# Altium schematic sheet Power.SchDoc(Power)
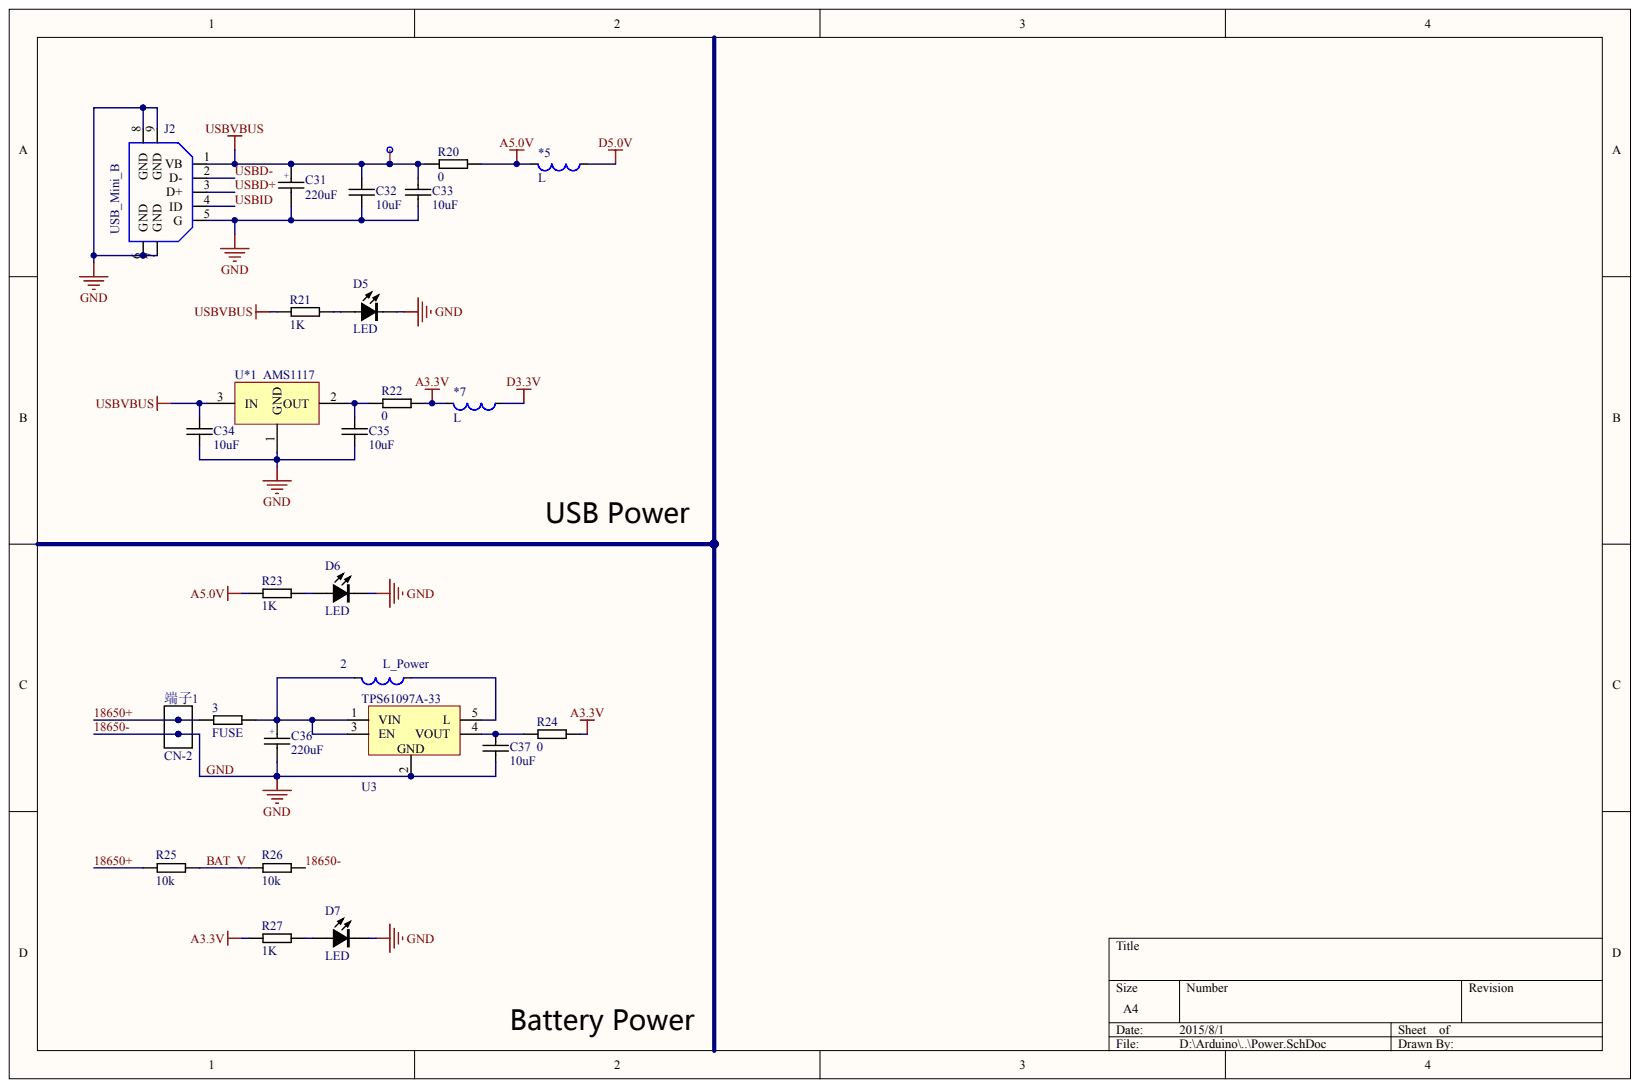
[diagram p0: Power.SchDoc]

## Parts
2  L_Power
3  FUSE
*5  L
*6  Point  (value not drawn)
*7  L
C31  220uF
C32  10uF
C33  10uF
C34  10uF
C35  10uF
C36  220uF
C37  10uF
D5  LED
D6  LED
D7  LED
J2  USB_Mini_B
R20  0
R21  1K
R22  0
R23  1K
R24  0
R25  10k
R26  10k
R27  1K
U3  TPS61097A-33
U*1  AMS1117
端子1  CN-2

## Nets
A3.3V: *7.1 R22.2 R24.2 R27.1
A5.0V: *5.1 R20.2 R23.1
D3.3V: *7.2
D5.0V: *5.2
USBVBUS: *6.1 C31.2 C32.1 C33.1 C34.1 J2.1(VB) R20.1 R21.1 U*1.3
18650+: 3.1 R25.1 端子1.1
18650-: C31.1 C32.2 C33.2 C34.2 C35.2 C36.1 C37.2 D5.2 D6.2 D7.2 J2.5(G) J2.6(GND) J2.7(GND) J2.8(GND) J2.9(GND) R26.2 U3.2 U*1.1 端子1.2
BAT_V: R25.2 R26.1
USBD+: J2.3(D+)
USBD-: J2.2(D-)
USBID: J2.4(ID)
Net2_1: 2.1 3.2 C36.2 U3.1(VIN) U3.3(EN)
Net2_2: 2.2 U3.5(L)
NetC35_1: C35.1 R22.1 U*1.2
NetC37_1: C37.1 R24.1 U3.4(VOUT)
NetD5_1: D5.1 R21.2
NetD6_1: D6.1 R23.2
NetD7_1: D7.1 R27.2
N00136:
